# Altium schematic sheet osd.SchDoc
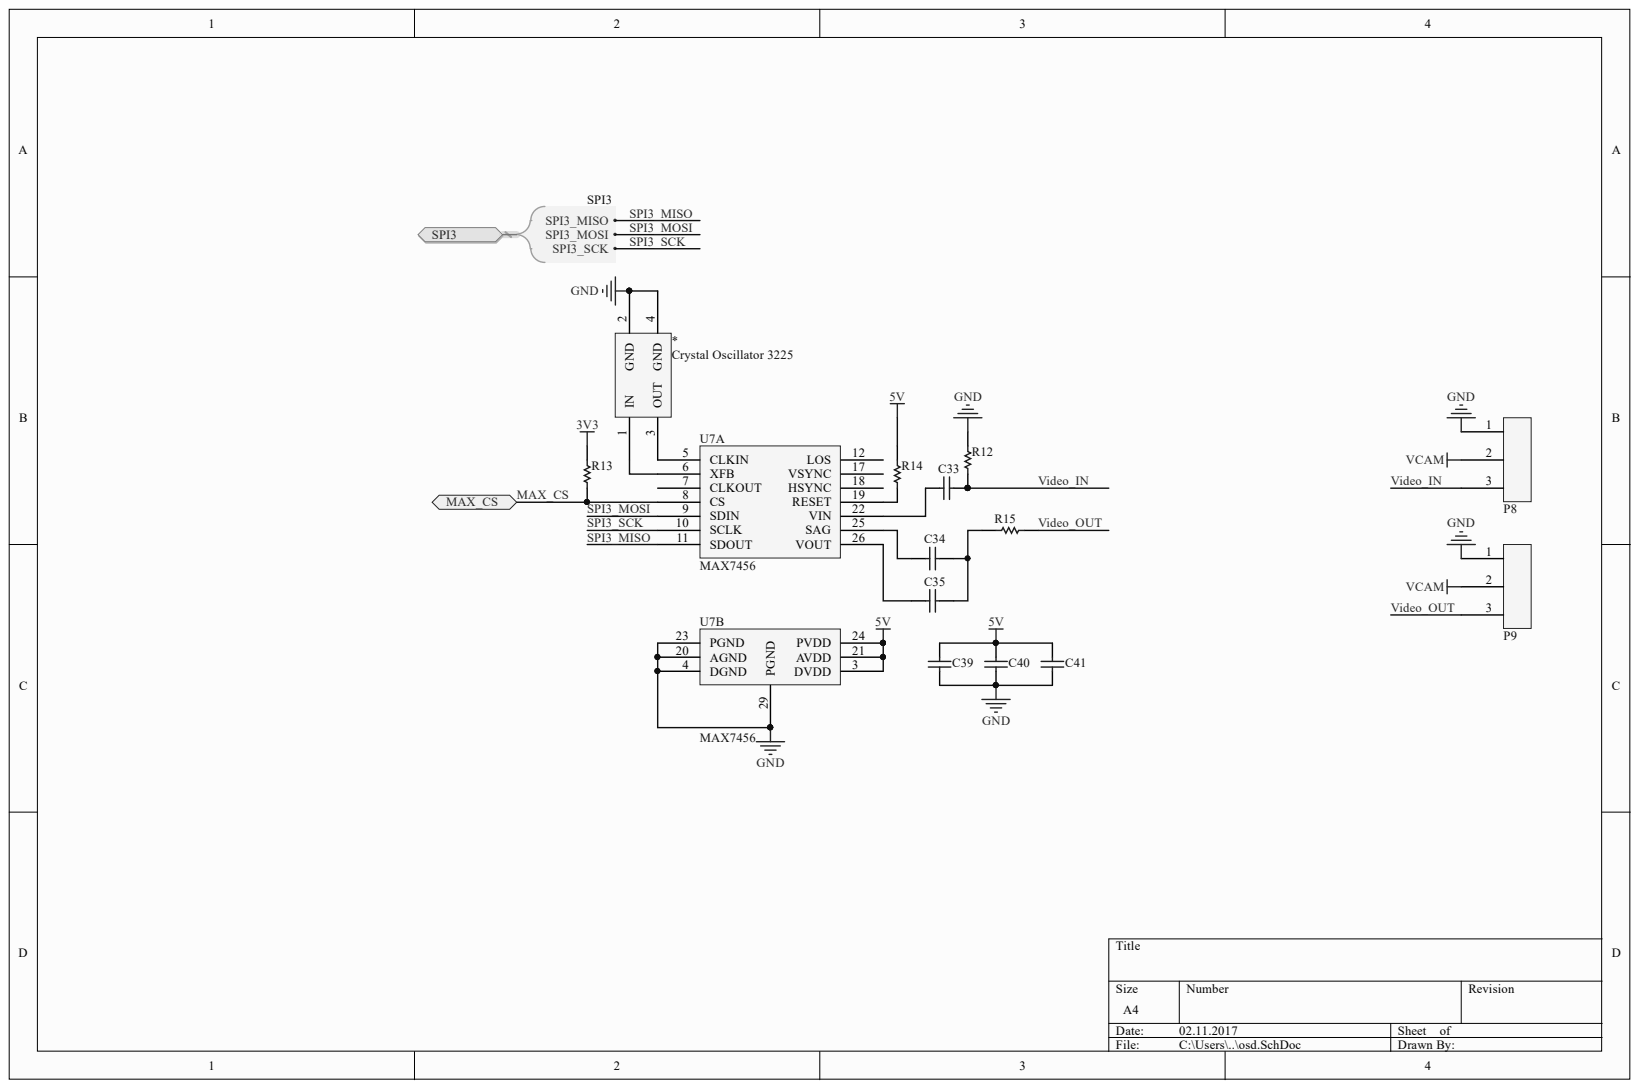
[diagram p0: osd.SchDoc]

## Parts
*  Crystal Oscillator 3225  [TSX-3225]
C33  CAP 100nF 25V 0603(1608)  [CAPC0603(1608)100_N]  (value not drawn)
C34  CAP 47uF 16V 1206(3216)  [CAPC1206(3216)190_M]  (value not drawn)
C35  CAP 47uF 16V 1206(3216)  [CAPC1206(3216)190_M]  (value not drawn)
C39  CAP 100nF 35V 0402(1005)  [CAPC0402(1005)60_L]  (value not drawn)
C40  CAP 100nF 35V 0402(1005)  [CAPC0402(1005)60_L]  (value not drawn)
C41  CAP 100nF 35V 0402(1005)  [CAPC0402(1005)60_L]  (value not drawn)
P8  3 Pads  [3Pads]  (value not drawn)
P9  3 Pads  [3Pads]  (value not drawn)
R12  75R 5% 0603(1608)  [RESC0603(1608)_N]  (value not drawn)
R13  10K 5% 0402(1005)  [RESC0402(1005)_N]  (value not drawn)
R14  10K 5% 0402(1005)  [RESC0402(1005)_L]  (value not drawn)
R15  75R 5% 0402(1005)  [RESC0402(1005)_L]  (value not drawn)
U7  MAX7456  [SOP28]  (parts A,B)

## Ports
MAX_CS, SPI3, SPI3.SPI3_MISO, SPI3.SPI3_MOSI, SPI3.SPI3_SCK

## Nets
3V3: R13.2
5V: C39.2 C40.2 C41.2 R14.2 U7.3(DVDD) U7.21(AVDD) U7.24(PVDD)
GND: *.2(GND) *.4(GND) C39.1 C40.1 C41.1 P8.1 P9.1 R12.2 U7.4(DGND) U7.20(AGND) U7.23(PGND) U7.29(PGND)
VCAM: P8.2 P9.2
MAX_CS: R13.1 U7.8(CS) ; port MAX_CS
SPI3_MISO: U7.11(SDOUT) ; port SPI3
SPI3_MOSI: U7.9(SDIN) ; port SPI3
SPI3_SCK: U7.10(SCLK) ; port SPI3
VIDEO_IN: C33.2 P8.3 R12.1
VIDEO_OUT: P9.3 R15.2
Net*_1: *.1(IN) U7.6(XFB)
Net*_3: *.3(OUT) U7.5(CLKIN)
NetC33_1: C33.1 U7.22(VIN)
NetC34_1: C34.1 U7.25(SAG)
NetC34_2: C34.2 C35.2 R15.1
NetC35_1: C35.1 U7.26(VOUT)
NetR14_1: R14.1 U7.19(RESET)
NetU7_7: U7.7(CLKOUT)
NetU7_12: U7.12(LOS)
NetU7_17: U7.17(VSYNC)
NetU7_18: U7.18(HSYNC)

## Library data of the parts
* = TSX-3225: Component Kind: Standard; Description: TSX-3225; Library Name: other.SchLib
C33 = CMP-1035-02589-1: CAP 100nF 25V ±20% 0603 (1608 Metric) Thickness 1mm SMD; Case-EIA: 0603; Case-Metric: 1608; Tolerance: Â±20%; Component Kind: Standard; Library Name: Altium Content Vault; Max Thickness: 1 mm; Rated Voltage: 25 V; Technology: SMT; Value: 100nF
C34 C35 = CMP-1037-05003-1: CAP 47uF 16V ±20% 1206 (3216 Metric) Thickness 1.9mm SMD; Case-EIA: 1206; Case-Metric: 3216; Tolerance: Â±20%; Component Kind: Standard; Library Name: Altium Content Vault; Max Thickness: 1.9 mm; Rated Voltage: 16 V; Technology: SMT; Value: 47uF
C39-C41 = CMP-1034-02075-1: CAP 100nF 35V ±10% 0402 (1005 Metric) Thickness 0.6mm SMD; Case-EIA: 0402; Case-Metric: 1005; Tolerance: Â±10%; Component Kind: Standard; Library Name: Altium Content Vault; Max Thickness: 0.6 mm; Rated Voltage: 35 V; Technology: SMT; Value: 100nF
R12 = CMP-1012-00047-1: 75R 0.1W 5% 0603 (1608 Metric)  SMD; Case-EIA: 0603; Case-Metric: 1608; Value: 75.00 Ohm; Component Kind: Standard; Library Name: Altium Content Vault; Power: 0.1 W; Technology: SMT; Tolerance: 5 %
R13 R14 = CMP-1011-00098-1: 10K 0.063W 5% 0402 (1005 Metric)  SMD; Case-EIA: 0402; Case-Metric: 1005; Value: 10000.00 Ohm; Component Kind: Standard; Library Name: Altium Content Vault; Power: 0.063 W; Technology: SMT; Tolerance: 5 %
R15 = CMP-1011-00047-1: 75R 0.063W 5% 0402 (1005 Metric)  SMD; Case-EIA: 0402; Case-Metric: 1005; Value: 75.00 Ohm; Component Kind: Standard; Library Name: Altium Content Vault; Power: 0.063 W; Technology: SMT; Tolerance: 5 %
P8 P9 = 3 Pads: Component Kind: Standard; Description: 3 Pads; Library Name: MyLib.IntLib
U7 = MAX7456: Component Kind: Standard; Description: MAX7456; Library Name: other.SchLib
